# Altium schematic sheet 2411_SDPSG_Schema.SchDoc
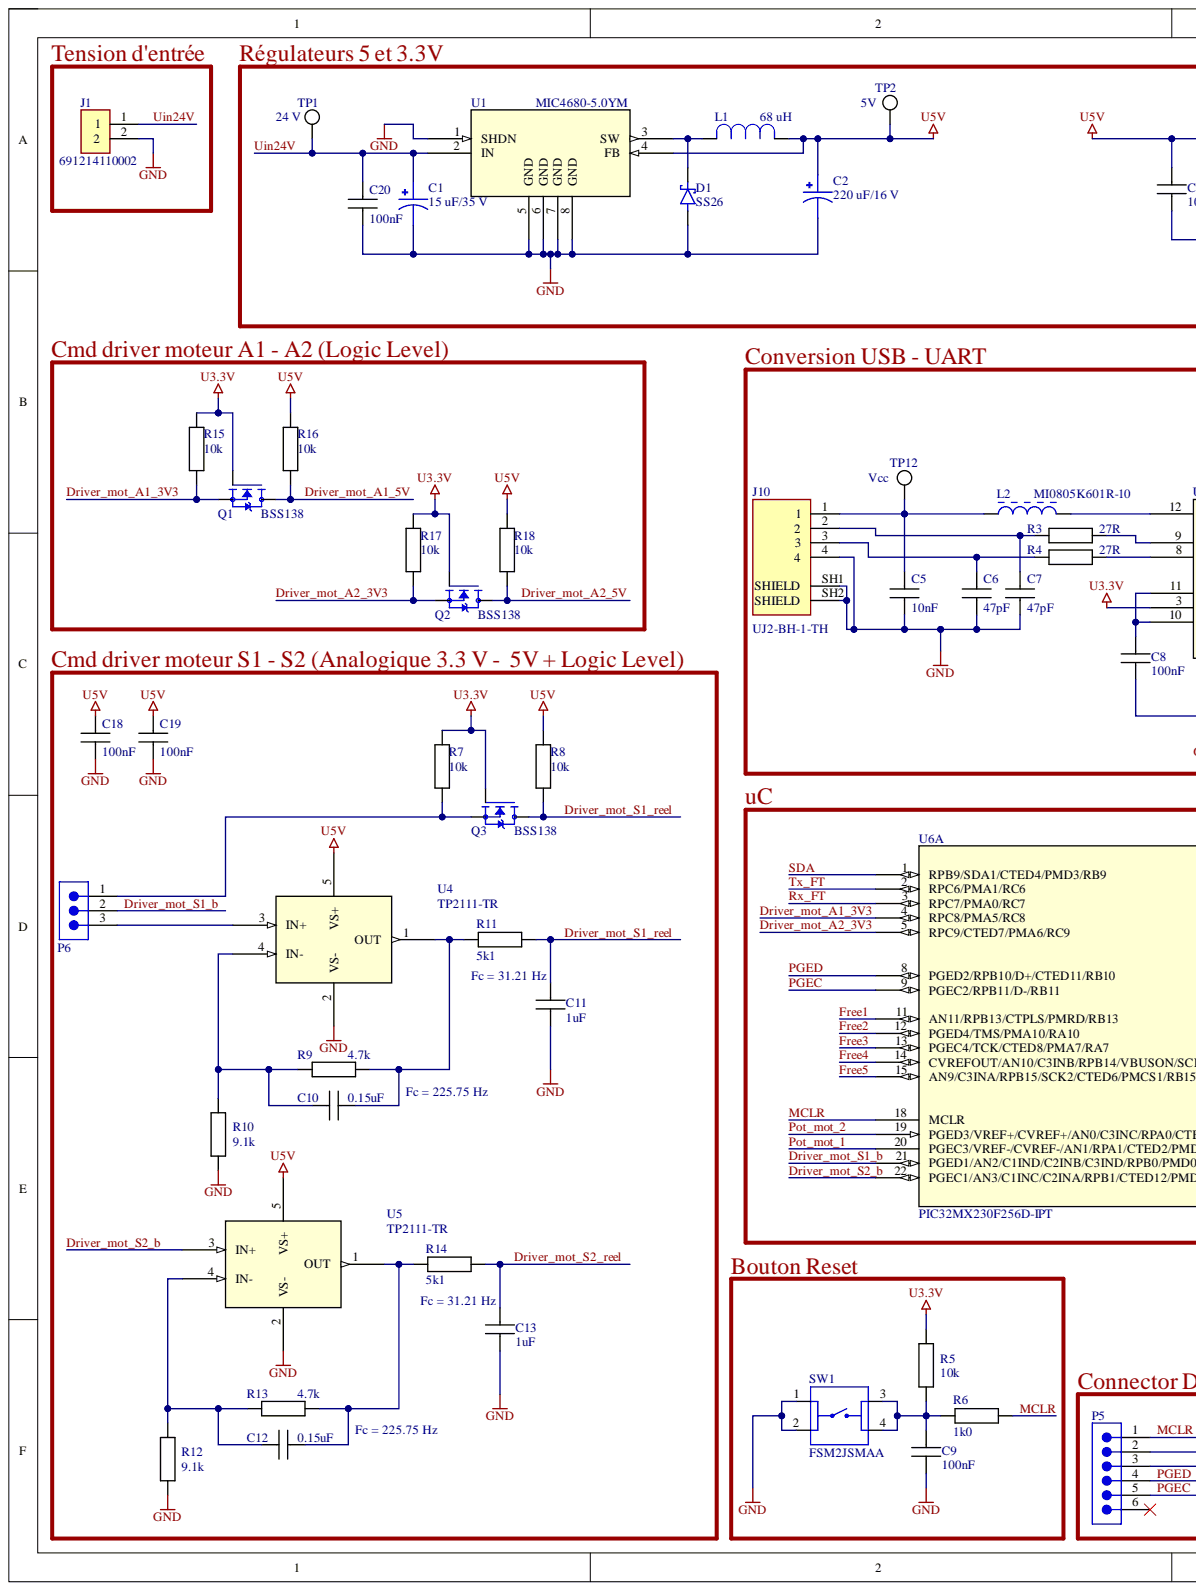
[diagram p0: 2411_SDPSG_Schema.SchDoc, left]
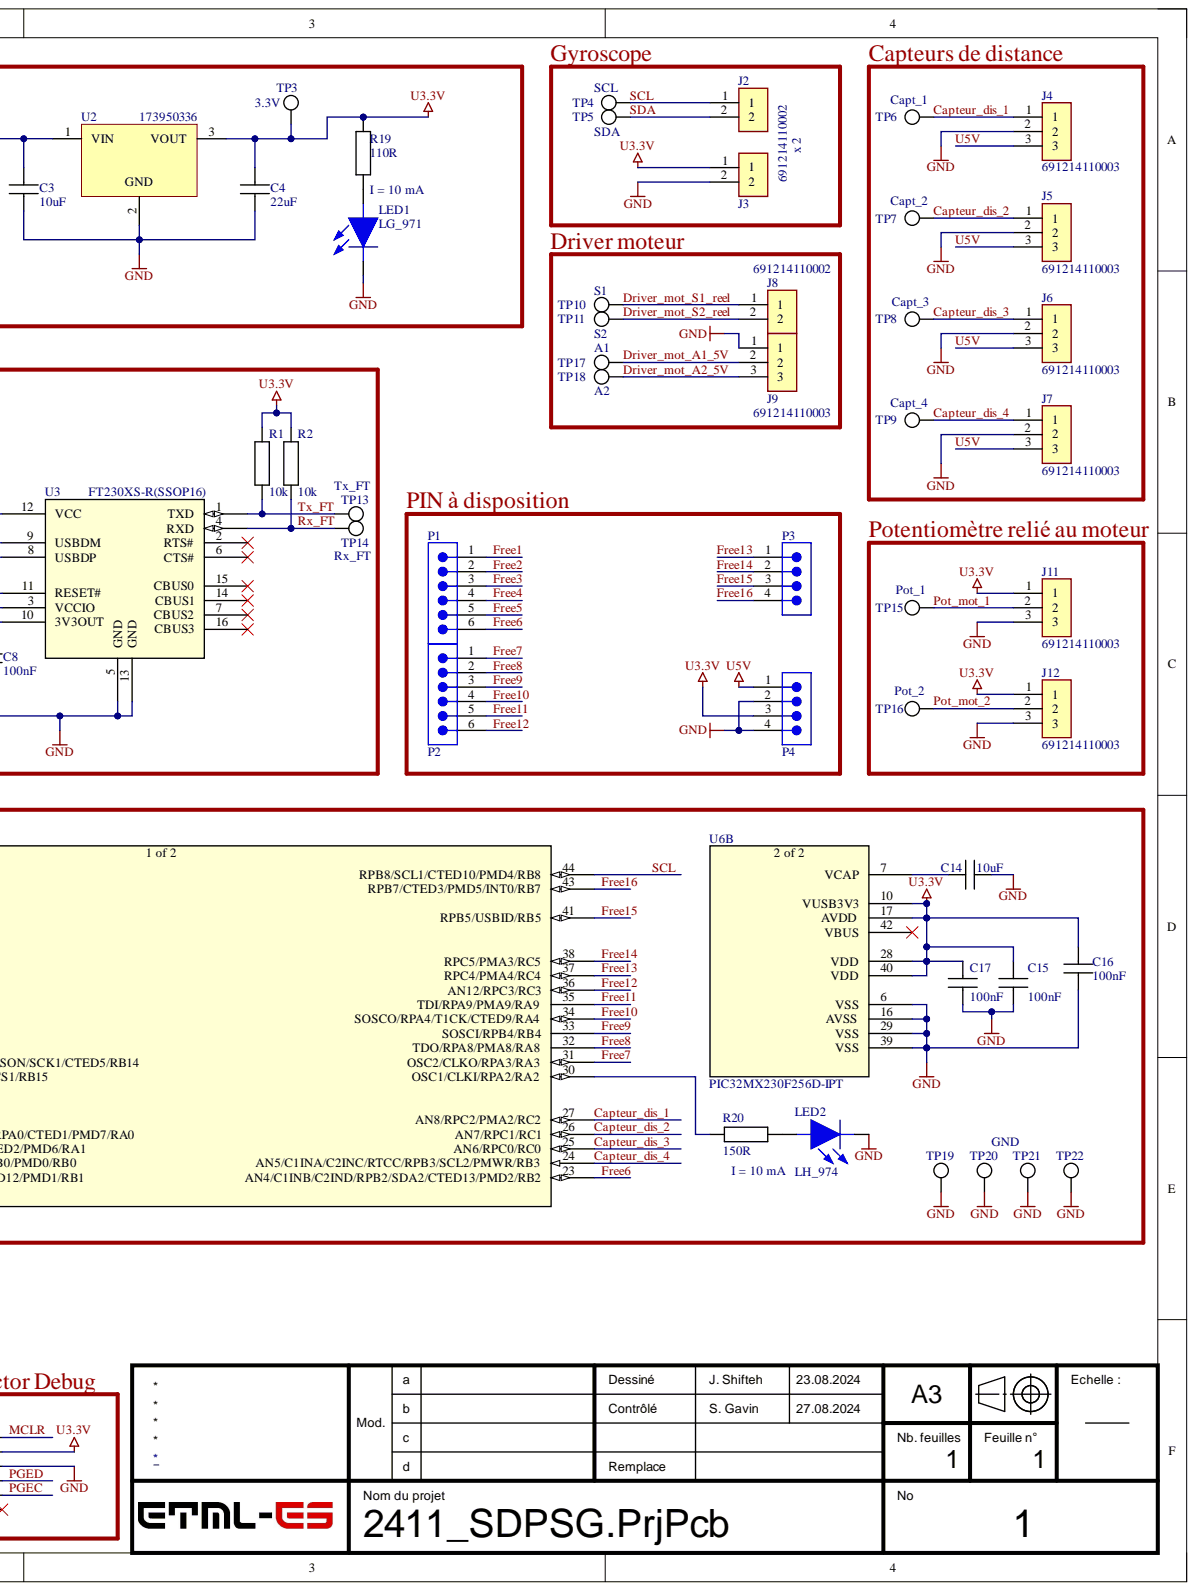
[diagram p1: 2411_SDPSG_Schema.SchDoc, right]

## Parts
C1  15 uF/35 V  [TPSD156M035R0300]
C2  220 uF/16 V  [TPSD227M010R0150]
C3  10uF  [CAPC2012X08M]
C4  22uF  [CAPC2012X08M]
C5  10nF  [CAPC2012X08M]
C6  47pF  [CAPC2012X08M]
C7  47pF  [CAPC2012X08M]
C8  100nF  [CAPC2012X08M]
C9  100nF  [CAPC2012X08M]
C10  0.15uF  [CAPC2012X08M]
C11  1uF  [CAPC2012X08M]
C12  0.15uF  [CAPC2012X08M]
C13  1uF  [CAPC2012X08M]
C14  10uF  [CAPC2012X08M]
C15  100nF  [CAPC2012X08M]
C16  100nF  [CAPC2012X08M]
C17  100nF  [CAPC2012X08M]
C18  100nF  [CAPC2012X08M]
C19  100nF  [CAPC2012X08M]
C20  100nF  [CAPC2012X08M]
D1  SS26  [SS26]
J1  691214110002  [691214110002]
J2  691214110002  [691214110002]
J3  691214110002  [691214110002]
J4  691214110003  [691214110003]
J5  691214110003  [691214110003]
J6  691214110003  [691214110003]
J7  691214110003  [691214110003]
J8  691214110002  [691214110002]
J9  691214110003  [691214110003]
J10  UJ2-BH-1-TH  [UJ2-BH-1-TH]
J11  691214110003  [691214110003]
J12  691214110003  [691214110003]
L1  68 uH  [UP2B-680-R]
L2  MI0805K601R-10  [LIRD-MI0805K601R-10-2_V]
LED1  LG_971  [LED2012Metric]
LED2  LH_974  [LED2012Metric]
P1  61300611121  [61300611121]  (value not drawn)
P2  61300611121  [61300611121]  (value not drawn)
P3  61300411121  [61300411121]  (value not drawn)
P4  61300411121  [61300411121]  (value not drawn)
P5  61300611121  [61300611121]  (value not drawn)
P6  61300311121  [61300311121]  (value not drawn)
Q1  BSS138  [BSS138]
Q2  BSS138  [BSS138]
Q3  BSS138  [BSS138]
R1  10k  [RESC2012X06M]
R2  10k  [RESC2012X06M]
R3  27R  [RESC2012X06M]
R4  27R  [RESC2012X06M]
R5  10k  [RESC2012X06M]
R6  1k0  [RESC2012X06M]
R7  10k  [RESC2012X06M]
R8  10k  [RESC2012X06M]
R9  4.7k  [RESC2012X06M]
R10  9.1k  [RESC2012X06M]
R11  5k1  [RESC2012X06M]
R12  9.1k  [RESC2012X06M]
R13  4.7k  [RESC2012X06M]
R14  5k1  [RESC2012X06M]
R15  10k  [RESC2012X06M]
R16  10k  [RESC2012X06M]
R17  10k  [RESC2012X06M]
R18  10k  [RESC2012X06M]
R19  110R  [RESC2012X06M]
R20  150R  [RESC2012X06M]
SW1  FSM2JSMAA  [FSM2JSMAA]
TP1  TestPoint_5019  [KEYSTONE_5019]  (value not drawn)
TP2  TestPoint_5019  [KEYSTONE_5019]  (value not drawn)
TP3  TestPoint_5019  [KEYSTONE_5019]  (value not drawn)
TP4  TestPoint_5019  [KEYSTONE_5019]  (value not drawn)
TP5  TestPoint_5019  [KEYSTONE_5019]  (value not drawn)
TP6  TestPoint_5019  [KEYSTONE_5019]  (value not drawn)
TP7  TestPoint_5019  [KEYSTONE_5019]  (value not drawn)
TP8  TestPoint_5019  [KEYSTONE_5019]  (value not drawn)
TP9  TestPoint_5019  [KEYSTONE_5019]  (value not drawn)
TP10  TestPoint_5019  [KEYSTONE_5019]  (value not drawn)
TP11  TestPoint_5019  [KEYSTONE_5019]  (value not drawn)
TP12  TestPoint_5019  [KEYSTONE_5019]  (value not drawn)
TP13  TestPoint_5019  [KEYSTONE_5019]  (value not drawn)
TP14  TestPoint_5019  [KEYSTONE_5019]  (value not drawn)
TP15  TestPoint_5019  [KEYSTONE_5019]  (value not drawn)
TP16  TestPoint_5019  [KEYSTONE_5019]  (value not drawn)
TP17  TestPoint_5019  [KEYSTONE_5019]  (value not drawn)
TP18  TestPoint_5019  [KEYSTONE_5019]  (value not drawn)
TP19  TestPoint_5019  [KEYSTONE_5019]  (value not drawn)
TP20  TestPoint_5019  [KEYSTONE_5019]  (value not drawn)
TP21  TestPoint_5019  [KEYSTONE_5019]  (value not drawn)
TP22  TestPoint_5019  [KEYSTONE_5019]  (value not drawn)
U1  MIC4680-5.0YM  [MIC4680-5.0YM]
U2  173950336  [173950336_Reg_3.3V]
U3  FT230XS-R(SSOP16)  [FT230XS-R(SSOP16)]
U4  TP2111-TR  [TP2111-TR]
U5  TP2111-TR  [TP2111-TR]
U6  PIC32MX230F256D-IPT  [PIC32MX230F256D-IPT]  (value not drawn)  (parts A,B)

## Nets
GND: C1.2 C2.2 C3.1 C4.1 C5.1 C6.1 C7.1 C8.1 C9.1 C11.1 C13.1 C14.2 C15.2 C16.1 C17.2 C18.1 C19.1 C20.1 D1.A J1.2 J3.2 J4.2 J5.2 J6.2 J7.2 J9.1 J10.4 J10.SH1(SHIELD) J10.SH2(SHIELD) J11.3 J12.3 LED1.1 LED2.1 P4.2 P4.4 P5.3 R10.1 R12.1 SW1.1 SW1.2 TP19.1 TP20.1 TP21.1 TP22.1 U1.1(SHDN) U1.5(GND) U1.6(GND) U1.7(GND) U1.8(GND) U2.2 U3.5(GND) U3.13(GND) U4.2(VS-) U5.2(VS-) U6.6(VSS) U6.16(AVSS) U6.29(VSS) U6.39(VSS)
U3.3V: C4.2 C15.1 C16.2 C17.1 J3.1 J11.1 J12.1 P4.3 P5.2 Q1.1 Q2.1 Q3.1 R1.2 R2.2 R5.2 R7.2 R15.2 R17.2 R19.2 TP3.1 U2.3 U3.3(VCCIO) U6.10(VUSB3V3) U6.17(AVDD) U6.28(VDD) U6.40(VDD)
Capteur_dis_1: J4.1 TP6.1 U6.27(AN8/RPC2/PMA2/RC2)
Capteur_dis_2: J5.1 TP7.1 U6.26(AN7/RPC1/RC1)
Capteur_dis_3: J6.1 TP8.1 U6.25(AN6/RPC0/RC0)
Capteur_dis_4: J7.1 TP9.1 U6.24(AN5/C1INA/C2INC/RTCC/RPB3/SCL2/PMWR/RB3)
Driver_mot_A1_3V3: Q1.2 R15.1 U6.4(RPC8/PMA5/RC8)
Driver_mot_A1_5V: J9.2 Q1.3 R16.1 TP17.1
Driver_mot_A2_3V3: Q2.2 R17.1 U6.5(RPC9/CTED7/PMA6/RC9)
Driver_mot_A2_5V: J9.3 Q2.3 R18.1 TP18.1
Driver_mot_S1_b: P6.2 U6.21(PGED1/AN2/C1IND/C2INB/C3IND/RPB0/PMD0/RB0)
Driver_mot_S1_reel: C11.2 J8.1 Q3.3 R8.1 R11.2 TP10.1
Driver_mot_S2_b: U5.3(IN+) U6.22(PGEC1/AN3/C1INC/C2INA/RPB1/CTED12/PMD1/RB1)
Driver_mot_S2_reel: C13.2 J8.2 R14.2 TP11.1
Free1: P1.1 U6.11(AN11/RPB13/CTPLS/PMRD/RB13)
Free2: P1.2 U6.12(PGED4/TMS/PMA10/RA10)
Free3: P1.3 U6.13(PGEC4/TCK/CTED8/PMA7/RA7)
Free4: P1.4 U6.14(CVREFOUT/AN10/C3INB/RPB14/VBUSON/SCK1/CTED5/RB14)
Free5: P1.5 U6.15(AN9/C3INA/RPB15/SCK2/CTED6/PMCS1/RB15)
Free6: P1.6 U6.23(AN4/C1INB/C2IND/RPB2/SDA2/CTED13/PMD2/RB2)
Free7: P2.1 U6.31(OSC2/CLKO/RPA3/RA3)
Free8: P2.2 U6.32(TDO/RPA8/PMA8/RA8)
Free9: P2.3 U6.33(SOSCI/RPB4/RB4)
Free10: P2.4 U6.34(SOSCO/RPA4/T1CK/CTED9/RA4)
Free11: P2.5 U6.35(TDI/RPA9/PMA9/RA9)
Free12: P2.6 U6.36(AN12/RPC3/RC3)
Free13: P3.1 U6.37(RPC4/PMA4/RC4)
Free14: P3.2 U6.38(RPC5/PMA3/RC5)
Free15: P3.3 U6.41(RPB5/USBID/RB5)
Free16: P3.4 U6.43(RPB7/CTED3/PMD5/INT0/RB7)
MCLR: P5.1 R6.2 U6.18(MCLR)
PGEC: P5.5 U6.9(PGEC2/RPB11/D-/RB11)
PGED: P5.4 U6.8(PGED2/RPB10/D+/CTED11/RB10)
Pot_mot_1: J11.2 TP15.1 U6.20(PGEC3/VREF-/CVREF-/AN1/RPA1/CTED2/PMD6/RA1)
Pot_mot_2: J12.2 TP16.1 U6.19(PGED3/VREF+/CVREF+/AN0/C3INC/RPA0/CTED1/PMD7/RA0)
Rx_FT: R2.1 TP14.1 U3.4(RXD) U6.3(RPC7/PMA0/RC7)
SCL: J2.1 TP4.1 U6.44(RPB8/SCL1/CTED10/PMD4/RB8)
SDA: J2.2 TP5.1 U6.1(RPB9/SDA1/CTED4/PMD3/RB9)
Tx_FT: R1.1 TP13.1 U3.1(TXD) U6.2(RPC6/PMA1/RC6)
U5V: C2.1 C3.2 C18.2 C19.2 J4.3 J5.3 J6.3 J7.3 L1.1 P4.1 R8.2 R16.2 R18.2 TP2.1 U1.4(FB) U2.1 U4.5(VS+) U5.5(VS+)
Uin24V: C1.1 C20.2 J1.1 TP1.1 U1.2(IN)
NetC5_2: C5.2 J10.1 L2.1 TP12.1
NetC6_2: C6.2 J10.3 R4.1
NetC7_2: C7.2 J10.2 R3.1
NetC8_2: C8.2 U3.10(3V3OUT) U3.11(RESET#)
NetC9_2: C9.2 R5.1 R6.1 SW1.3 SW1.4
NetC10_1: C10.1 R9.1 R11.1 U4.1(OUT)
NetC10_2: C10.2 R9.2 R10.2 U4.4(IN-)
NetC12_1: C12.1 R13.1 R14.1 U5.1(OUT)
NetC12_2: C12.2 R12.2 R13.2 U5.4(IN-)
NetC14_1: C14.1 U6.7(VCAP)
NetD1_C: D1.C L1.2 U1.3(SW)
NetL2_2: L2.2 U3.12(VCC)
NetLED1_2: LED1.2 R19.1
NetLED2_2: LED2.2 R20.1
NetP6_1: P6.1 Q3.2 R7.1
NetP6_3: P6.3 U4.3(IN+)
NetR3_2: R3.2 U3.9(USBDM)
NetR4_2: R4.2 U3.8(USBDP)
NetR20_2: R20.2 U6.30(OSC1/CLKI/RPA2/RA2)

Not connected: P5 6; U3 2(RTS#) 6(CTS#) 7(CBUS2) 14(CBUS1) 15(CBUS0) 16(CBUS3); U6 42(VBUS)

## Library data of the parts
C1 = TPSD156M035R0300: Capacitor,Tantalum,Cap 15 uF,Tol 20%,Vol-Rtg 35 VDC,SMT,7343,Low ESR,Tape & Reel | AVX TPSD156M035R0300; Check_prices: https://www.snapeda.com/parts/TPSD156M035R0300/AVX/view-part/?ref=eda; Component Kind: Standard; MF: AVX; MP: TPSD156M035R0300; Package: 2917 AVX; SnapEDA_Link: https://www.snapeda.com/parts/TPSD156M035R0300/AVX/view-part/?ref=snap; Library Name: 2411_SDPSG_Lib_Schematic.Schlib
C2 = TPSD227M010R0150: Condensador electrolÃ­tico de tantalio MnO220 sÃ³lido SMD 2uF, 10 V dc +/-20%, serie TPS | AVX TPSD227M010R0150; Check_prices: https://www.snapeda.com/parts/TPSD227M010R0150/AVX/view-part/?ref=eda; Component Kind: Standard; MF: AVX; MP: TPSD227M010R0150; Package: 2917 AVX; SnapEDA_Link: https://www.snapeda.com/parts/TPSD227M010R0150/AVX/view-part/?ref=snap; Library Name: 2411_SDPSG_Lib_Schematic.Schlib
C3 C14 = CMP-065-000040-2: CAPC 10uF X5R 16V 10% 2012 (0805 INCH); Manufacturer: TDK; Manufacturer Number: C2012X5R1C106K085AC; IsStocked: 1; PN: PN-654987; TemperatureRange: -55°C to +85°C; Value: 10µF; Category: Passives; Component Kind: Standard; Dielectric: X5R; Library Name: ETML-ES; SubCategory: Condensateurs ceramique; Supplier 1: ETML; Supplier Part Number 1: FAB.0805_C 10u; Supplier Price 1: 0.0360; Tolerance: ±10%; Voltage: 16V
C4 = CMP-065-000040-2: CAPC 22uF X5R 16V 10% 2012 (0805 INCH); Manufacturer: TDK; Manufacturer Number: C2012X5R1C106K085AC; IsStocked: 1; PN: PN-654987; TemperatureRange: -55°C to +85°C; Value: 10µF; Category: Passives; Component Kind: Standard; Dielectric: X5R; Library Name: ETML-ES; SubCategory: Condensateurs ceramique; Supplier 1: ETML; Supplier Part Number 1: FAB.0805_C 10u; Supplier Price 1: 0.0360; Tolerance: ±10%; Voltage: 16V
C5 = CMP-065-000040-2: CAPC 10nF X5R 16V 10% 2012 (0805 INCH); Manufacturer: TDK; Manufacturer Number: C2012X5R1C106K085AC; IsStocked: 1; PN: PN-654987; TemperatureRange: -55°C to +85°C; Value: 10µF; Category: Passives; Component Kind: Standard; Dielectric: X5R; Library Name: ETML-ES; SubCategory: Condensateurs ceramique; Supplier 1: ETML; Supplier Part Number 1: FAB.0805_C 10u; Supplier Price 1: 0.0360; Tolerance: ±10%; Voltage: 16V
C6 C7 = CMP-065-000040-2: CAPC 47pF X5R 16V 10% 2012 (0805 INCH); Manufacturer: TDK; Manufacturer Number: C2012X5R1C106K085AC; IsStocked: 1; PN: PN-654987; TemperatureRange: -55°C to +85°C; Value: 10µF; Category: Passives; Component Kind: Standard; Dielectric: X5R; Library Name: ETML-ES; SubCategory: Condensateurs ceramique; Supplier 1: ETML; Supplier Part Number 1: FAB.0805_C 10u; Supplier Price 1: 0.0360; Tolerance: ±10%; Voltage: 16V
C8 C9 C15-C20 = CMP-065-000038-2: CAPC 100nF X7R 50V 10% 2012 (0805 INCH); Manufacturer: TDK; Manufacturer Number: C2012X7R1H104K085AA; IsStocked: 1; PN: PN-747862; TemperatureRange: -55°C to +125°C; Category: Passives; Component Kind: Standard; Dielectric: X7R; Library Name: ETML-ES; SubCategory: Condensateurs ceramique; Supplier 1: ETML; Supplier Part Number 1: FAB.0805_C 100n; Supplier Price 1: 0.0200; Tolerance: ±10%; Value: 100nF; Voltage: 50V
C10 C12 = CMP-065-000041-2: CAPC 0.15uF X7R 25V 10% 2012 (0805 INCH); Manufacturer: TDK; Manufacturer Number: C2012X5R1E105K125AA; Dielectric: X5R; IsStocked: 1; PN: PN-324029; TemperatureRange: -55°C to +85°C; Value: 1µF; Category: Passives; Component Kind: Standard; Library Name: ETML-ES; SubCategory: Condensateurs ceramique; Supplier 1: ETML; Supplier Part Number 1: FAB.0805_C 1u; Supplier Price 1: 0.0223; Tolerance: ±10%; Voltage: 25V
C11 C13 = CMP-065-000041-2: CAPC 1uF X5R 25V 10% 2012 (0805 INCH); Manufacturer: TDK; Manufacturer Number: C2012X5R1E105K125AA; IsStocked: 1; PN: PN-324029; TemperatureRange: -55°C to +85°C; Value: 1µF; Category: Passives; Component Kind: Standard; Dielectric: X5R; Library Name: ETML-ES; SubCategory: Condensateurs ceramique; Supplier 1: ETML; Supplier Part Number 1: FAB.0805_C 1u; Supplier Price 1: 0.0223; Tolerance: ±10%; Voltage: 25V
D1 = SS26: 2A, 60V, Schottky Rectifier; MF: Taiwan Semiconductor; Check_prices: https://www.snapeda.com/parts/SS26/Taiwan+Semiconductor/view-part/?ref=eda; Component Kind: Standard; MP: SS26; Package: SMB-2 Taiwan Semiconductor; SnapEDA_Link: https://www.snapeda.com/parts/SS26/Taiwan+Semiconductor/view-part/?ref=snap; Library Name: 2411_SDPSG_Lib_Schematic.Schlib
J1-J3 J8 = 691214110002: 3.5MM HORIZONTAL ENTRY MODULAR WITH RISING CAGE CLAMP - WR-TBL, 2 pos; Manufacturer_Name: Wurth Elektronik; Geometry.Height: 8.7mm; Component Kind: Standard; Datasheet Link: https://eu.mouser.com/datasheet/2/445/691214110002-1719445.pdf; Manufacturer_Part_Number: 691214110002; Mouser Part Number: 710-691214110002; Mouser Price/Stock: https://www.mouser.co.uk/ProductDetail/Wurth-Elektronik/691214110002?qs=7gQLVZk5cPmb9EqUyfv%252B2g%3D%3D; Library Name: 2411_SDPSG_Lib_Schematic.Schlib
J4-J7 J9 J11 J12 = 691214110003: 3.5MM HORIZONTAL ENTRY MODULAR WITH RISING CAGE CLAMP - WR-TBL, 3 pos; Manufacturer_Name: Wurth Elektronik; Manufacturer_Part_Number: 691214110002; Geometry.Height: 8.7mm; Component Kind: Standard; Datasheet Link: https://eu.mouser.com/datasheet/2/445/691214110002-1719445.pdf; Mouser Part Number: 710-691214110002; Mouser Price/Stock: https://www.mouser.co.uk/ProductDetail/Wurth-Elektronik/691214110002?qs=7gQLVZk5cPmb9EqUyfv%252B2g%3D%3D; Library Name: 2411_SDPSG_Lib_Schematic.Schlib
J10 = UJ2-BH-1-TH: Type B, USB 2.0, 1 A, Right Angle, Through Hole, USB Receptacle; MF: CUI Devices; Availability: In Stock; Check_prices: https://www.snapeda.com/parts/UJ2-BH-1-TH/CUI+Devices/view-part/?ref=eda; Component Kind: Standard; CUI_purchase_URL: https://www.cuidevices.com/product/interconnect/connectors/usb-connectors/uj2-bh-th-series?utm_source=snapeda.com&utm_medium=referral&utm_campaign=snapedaBOM; MP: UJ2-BH-1-TH; Package: None; Price: None; Purchase-URL: https://pricing.snapeda.com/search/part/UJ2-BH-1-TH/?ref=eda; SnapEDA_Link: https://www.snapeda.com/parts/UJ2-BH-1-TH/CUI+Devices/view-part/?ref=snap; Library Name: 2411_SDPSG_Lib_Schematic.Schlib
L1 = UP2B-680-R: POWER INDUCTOR; Availability: Unavailable; Component Kind: Standard; MP: UP2B-680-R; Package: None; Price: None; Library Name: 2411_SDPSG_Lib_Schematic.Schlib
L2 = CMP-2000-05196-1: Chip Bead for Power Filtering, 1500 mA, 600 Ohm, -40 to 125 degC, 0805 (2012 Metric), RoHS, Tape and Reel; Manufacturer: Laird; Catalog Drawings: (HI,HZ,LI,MI)0805Series_1.10mmMax.Height; Current Rating (Max): 1.5A; DC Resistance (DCR) (Max): 100mOhm; Filter Type: PowerLine; Impedance @ Frequency: 600Ohm@100MHz; Kits: 240-2227-ND-KITEMIFERRITEBEADCHIPSMD; Number of Lines: 1; Online Catalog: MISeries; Other Names: 240-2390-1; Category: Filters; Component Kind: Standard; Datasheet URL: https://datasheet.ciiva.com/3082/mi0805k601r-10-a-vp-3082219.pdf; Datasheet Version: Rev. A, 01/2004; Family: FerriteBeadsandChips; Height (Max): 0.043"(1.10mm); Library Name: Altium Content Vault; Manufacturer URL: https://www.lairdtech.com; Mounting Type: SurfaceMount; Operating Temperature: -40degC~125degC; Package / Case: 0805(2012Metric); Package Description: 2-Pin Surface Mount Device, Body 2 x 1.25 mm; Package Reference: MI0805K601R-10-2; Packaging: CutTape(CT); Ratings: -; Series: MI; Size / Dimension: 0.079"Lx0.049"W(2.00mmx1.25mm); Standard Package: 1
LED1 = LG_971: Green 570nm LED Indication - Discrete 2.2V 0805 (2012 Metric); MF: OSRAM Opto; MP: LG R971; Check_prices: https://www.snapeda.com/parts/LG%20R971/OSRAM+Opto+Semiconductors+Inc./view-part/?ref=eda; Component Kind: Standard; Package: 0805 Osram Opto; SnapEDA_Link: https://www.snapeda.com/parts/LG%20R971/OSRAM+Opto+Semiconductors+Inc./view-part/?ref=snap; Library Name: 2411_SDPSG_Lib_Schematic.Schlib
LED2 = LH_974: Red 570nm LED Indication - Discrete 1.8V 0805 (2012 Metric); MF: OSRAM Opto; MP: LG R971; Check_prices: https://www.snapeda.com/parts/LG%20R971/OSRAM+Opto+Semiconductors+Inc./view-part/?ref=eda; Component Kind: Standard; Package: 0805 Osram Opto; SnapEDA_Link: https://www.snapeda.com/parts/LG%20R971/OSRAM+Opto+Semiconductors+Inc./view-part/?ref=snap; Library Name: 2411_SDPSG_Lib_Schematic.Schlib
P1 P2 P5 = 61300611121: WR-PHD Pin Header, THT, Vertical, pitch 2.54mm, 1 Row, 6P; Manufacturer: Wurth Elektronik; Contact Resistance: 20mOhm; Rated Current: 3A; Withstanding Voltage: 500V; Working Voltage: 250V; Category: Board-to-Board Connectors; Component Kind: Standard; ComponentLink1Description: Website Link; ComponentLink1URL: https://www.we-online.com/redexpert/article/61300611121?ad; ComponentLink2Description: Datasheet Link; ComponentLink2URL: https://www.we-online.com/redexpert/spec/61300611121?ad; Gender: Pin Header; Manufacturer Part Number: 61300611121; Match Code: WR-PHD; Mount: Through Hole; Number of Pins: 6; Number of Rows: 1; Operating Temperature Max: 105°C; Operating Temperature Min: -40°C; Orientation: Vertical; Packaging: Bag; Pitch: 2.54mm; Library Name: 2411_SDPSG_Lib_Schematic.Schlib
P3 P4 = 61300411121: WR-PHD Pin Header, THT, Vertical, pitch 2.54mm, 1 Row, 4P; Manufacturer: Wurth Elektronik; Contact Resistance: 20mOhm; Rated Current: 3A; Withstanding Voltage: 500V; Working Voltage: 250V; Category: Board-to-Board Connectors; Component Kind: Standard; ComponentLink1Description: Website Link; ComponentLink1URL: https://www.we-online.com/redexpert/article/61300411121?ad; ComponentLink2Description: Datasheet Link; ComponentLink2URL: https://www.we-online.com/redexpert/spec/61300411121?ad; Gender: Pin Header; Manufacturer Part Number: 61300411121; Match Code: WR-PHD; Mount: Through Hole; Number of Pins: 4; Number of Rows: 1; Operating Temperature Max: 105°C; Operating Temperature Min: -40°C; Orientation: Vertical; Packaging: Bag; Pitch: 2.54mm; Library Name: 2411_SDPSG_Lib_Schematic.Schlib
P6 = 61300311121: WR-PHD Pin Header, THT, Vertical, pitch 2.54mm, 1 Row, 3P; Manufacturer: Wurth Elektronik; Contact Resistance: 20mOhm; Rated Current: 3A; Withstanding Voltage: 500V; Working Voltage: 250V; Category: Board-to-Board Connectors; Component Kind: Standard; ComponentLink1Description: Website Link; ComponentLink1URL: https://www.we-online.com/redexpert/article/61300311121?ad; ComponentLink2Description: Datasheet Link; ComponentLink2URL: https://www.we-online.com/redexpert/spec/61300311121?ad; Gender: Pin Header; Manufacturer Part Number: 61300311121; Match Code: WR-PHD; Mount: Through Hole; Number of Pins: 3; Number of Rows: 1; Operating Temperature Max: 105°C; Operating Temperature Min: -40°C; Orientation: Vertical; Packaging: Bag; Pitch: 2.54mm; Library Name: 2411_SDPSG_Lib_Schematic.Schlib
Q1-Q3 = BSS138: N-Channel 50V 220mA (Ta) 360mW (Ta) Surface Mount SOT-23-3; MF: ON Semiconductor; Availability: Good; Check_prices: https://www.snapeda.com/parts/BSS138/ON%20Semiconductor/view-part/4794929/?ref=eda; Component Kind: Standard; MP: BSS138; Package: SOT-23 ON Semiconductor; Price: None; Purchase-URL: https://pricing.snapeda.com/search/part/BSS138/?ref=eda; SnapEDA_Link: https://www.snapeda.com/parts/BSS138/ON%20Semiconductor/view-part/4794929/?ref=snap; Library Name: 2411_SDPSG_Lib_Schematic.Schlib
R1 R2 R5 R7 R8 R15-R18 = CMP-066-000199-2: RES 10kohm  ±1% 0.125W 2012 (0805 INCH); Manufacturer: Yageo; Manufacturer Number: RC0805FR-0710KL; IsStocked: 1; PN: PN-374909; TemperatureCoeff: ±200ppm/°C; TemperatureRange: -55°C to 155°C; Voltage: 150V; Category: Passives; Component Kind: Standard; Library Name: ETML-ES; Power: 0.125W; SubCategory: Resistances couche epaisse; Supplier 1: ETML; Supplier Part Number 1: FAB.0805_R 10k; Supplier Price 1: 0.0200; Tolerance: ±1%; Value: 10k
R3 R4 = CMP-066-000168-2: RES 27ohm  ±1% 0.125W 2012 (0805 INCH); Manufacturer: Yageo; Manufacturer Number: RC0805FR-0727RL; IsStocked: 1; PN: PN-734618; TemperatureCoeff: ±200ppm/°C; TemperatureRange: -55°C to 155°C; Value: 27; Voltage: 150V; Category: Passives; Component Kind: Standard; Library Name: ETML-ES; Power: 0.125W; SubCategory: Resistances couche epaisse; Supplier 1: ETML; Supplier Part Number 1: FAB.0805_R 27R; Supplier Price 1: 0.0200; Tolerance: ±1%
R6 = CMP-066-000187-2: RES 1.0kohm  ±1% 0.125W 2012 (0805 INCH); Manufacturer: Yageo; Manufacturer Number: RC0805FR-071KL; IsStocked: 1; PN: PN-746409; TemperatureCoeff: ±200ppm/°C; TemperatureRange: -55°C to 155°C; Value: 1k; Voltage: 150V; Category: Passives; Component Kind: Standard; Library Name: ETML-ES; Power: 0.125W; SubCategory: Resistances couche epaisse; Supplier 1: ETML; Supplier Part Number 1: FAB.0805_R 1k0; Supplier Price 1: 0.0200; Tolerance: ±1%
R9 R10 R12 R13 = CMP-066-000199-2: RES 10kohm  ±1% 0.125W 2012 (0805 INCH); Manufacturer: Yageo; Manufacturer Number: RC0805FR-0710KL; IsStocked: 1; PN: PN-374909; TemperatureCoeff: ±200ppm/°C; TemperatureRange: -55°C to 155°C; Value: 10k; Voltage: 150V; Category: Passives; Component Kind: Standard; Library Name: ETML-ES; Power: 0.125W; SubCategory: Resistances couche epaisse; Supplier 1: ETML; Supplier Part Number 1: FAB.0805_R 10k; Supplier Price 1: 0.0200; Tolerance: ±1%
R11 R14 = CMP-066-000196-2: RES 5.1kohm  ±1% 0.125W 2012 (0805 INCH); Manufacturer: Yageo; Manufacturer Number: RC0805FR-075K6L; IsStocked: 1; PN: PN-467784; TemperatureCoeff: ±200ppm/°C; TemperatureRange: -55°C to 155°C; Value: 5.6k; Voltage: 150V; Category: Passives; Component Kind: Standard; Library Name: ETML-ES; Power: 0.125W; SubCategory: Resistances couche epaisse; Supplier 1: ETML; Supplier Part Number 1: FAB.0805_R 5k6; Supplier Price 1: 0.0200; Tolerance: ±1%
R19 = CMP-066-000199-2: RES 110ohm  ±1% 0.125W 2012 (0805 INCH); Manufacturer: Yageo; Manufacturer Number: RC0805FR-0710KL; IsStocked: 1; PN: PN-374909; TemperatureCoeff: ±200ppm/°C; TemperatureRange: -55°C to 155°C; Value: 10k; Voltage: 150V; Category: Passives; Component Kind: Standard; Library Name: ETML-ES; Power: 0.125W; SubCategory: Resistances couche epaisse; Supplier 1: ETML; Supplier Part Number 1: FAB.0805_R 10k; Supplier Price 1: 0.0200; Tolerance: ±1%
R20 = CMP-066-000199-2: RES 150ohm  ±1% 0.125W 2012 (0805 INCH); Manufacturer: Yageo; Manufacturer Number: RC0805FR-0710KL; IsStocked: 1; PN: PN-374909; TemperatureCoeff: ±200ppm/°C; TemperatureRange: -55°C to 155°C; Value: 10k; Voltage: 150V; Category: Passives; Component Kind: Standard; Library Name: ETML-ES; Power: 0.125W; SubCategory: Resistances couche epaisse; Supplier 1: ETML; Supplier Part Number 1: FAB.0805_R 10k; Supplier Price 1: 0.0200; Tolerance: ±1%
SW1 = FSM2JSMAA: Tactile Switch SPST-NO Top Actuated Surface Mount; Configuration_Pole-Throw: Single Pole - Single Throw; Contact_Current_Rating: 50 mA; MF: TE Connectivity; MP: 2-1437565-8; Availability: Unavailable; Component Kind: Standard; Package: None; Price: None; SnapEDA_Link: https://www.snapeda.com/parts/2-1437565-8/TE%20Connectivity%20ALCOSWITCH%20Switches/view-part/455292/?ref=snap; TE_purchase_URL: https://www.te.com/usa-en/product-2-1437565-8.html?te_bu=Cor&te_type=disp&te_campaign=seda_glo_cor-seda-global-disp-prtnr-fy19-seda-model-bom-cta_sma-317_1&elqCampaignId=32493; Library Name: 2411_SDPSG_Lib_Schematic.Schlib
TP1-TP22 = TestPoint_5019: TEST Point SMD; Manufacturer: Keystone; Manufacturer Number: 5000; IsStocked: True; PN: PN-119866; Value: 5000; Category: Connectors; Component Kind: Standard; SubCategory: Testpoints; Supplier 1: ETML; Supplier Part Number 1: MAG.428.012; Supplier Price 1: 0.2708; Library Name: 2411_SDPSG_Lib_Schematic.Schlib
U1 = MIC4680-5.0YM: No Description Available; Mfr_Name: Microchip; Component Kind: Standard; RefDes: RefDes; Type: Type; Library Name: 2411_SDPSG_Lib_Schematic.Schlib
U2 = 173950336_Reg_3.3V: WPME-FDSM Fixed Step Down Regulator Module, THT, SIP-3, 4.75-36Vin, 3.3Vout, 0.5Aout; Manufacturer: Wurth Elektronik; Input Voltage Max: 36V; Input Voltage Min: 4.75V; Output Current: 0.5A; Output Voltage: 3.3V; Case/Size Code: SIP-3; Category: Step Down Converter; Component Kind: Standard; ComponentLink1Description: Website Link; ComponentLink1URL: https://www.we-online.com/redexpert/article/173950336?ad; ComponentLink2Description: Datasheet Link; ComponentLink2URL: https://www.we-online.com/redexpert/spec/173950336?ad; Height: 10.16mm; Length: 11.6mm; Manufacturer Part Number: 173950336; Match Code: WPME-FDSM; Mount: Through Hole; Operating Temperature Max: 85°C; Operating Temperature Min: -40°C; Packaging: Tube; Width: 7.55mm; Library Name: 2411_SDPSG_Lib_Schematic.Schlib
U3 = FT230XS-R(SSOP16): USB Bridge, USB to UART USB 2.0 UART Interface 16-SSOP; Mfr_Name: Microchip; Component Kind: Standard; RefDes: RefDes; Type: Type; Library Name: 2411_SDPSG_Lib_Schematic.Schlib
U4 U5 = TP2111-TR: No Description Available; Mfr_Name: 3Peak; Component Kind: Standard; RefDes: RefDes; Type: Type; Library Name: 2411_SDPSG_Lib_Schematic.Schlib
U6 = PIC32MX230F256D-IPT: No Description Available; Mfr_Name: Microchip; Component Kind: Standard; RefDes: RefDes; Type: Type; Library Name: 2411_SDPSG_Lib_Schematic.Schlib
